# Altium schematic sheet Active_Electrode.SchDoc
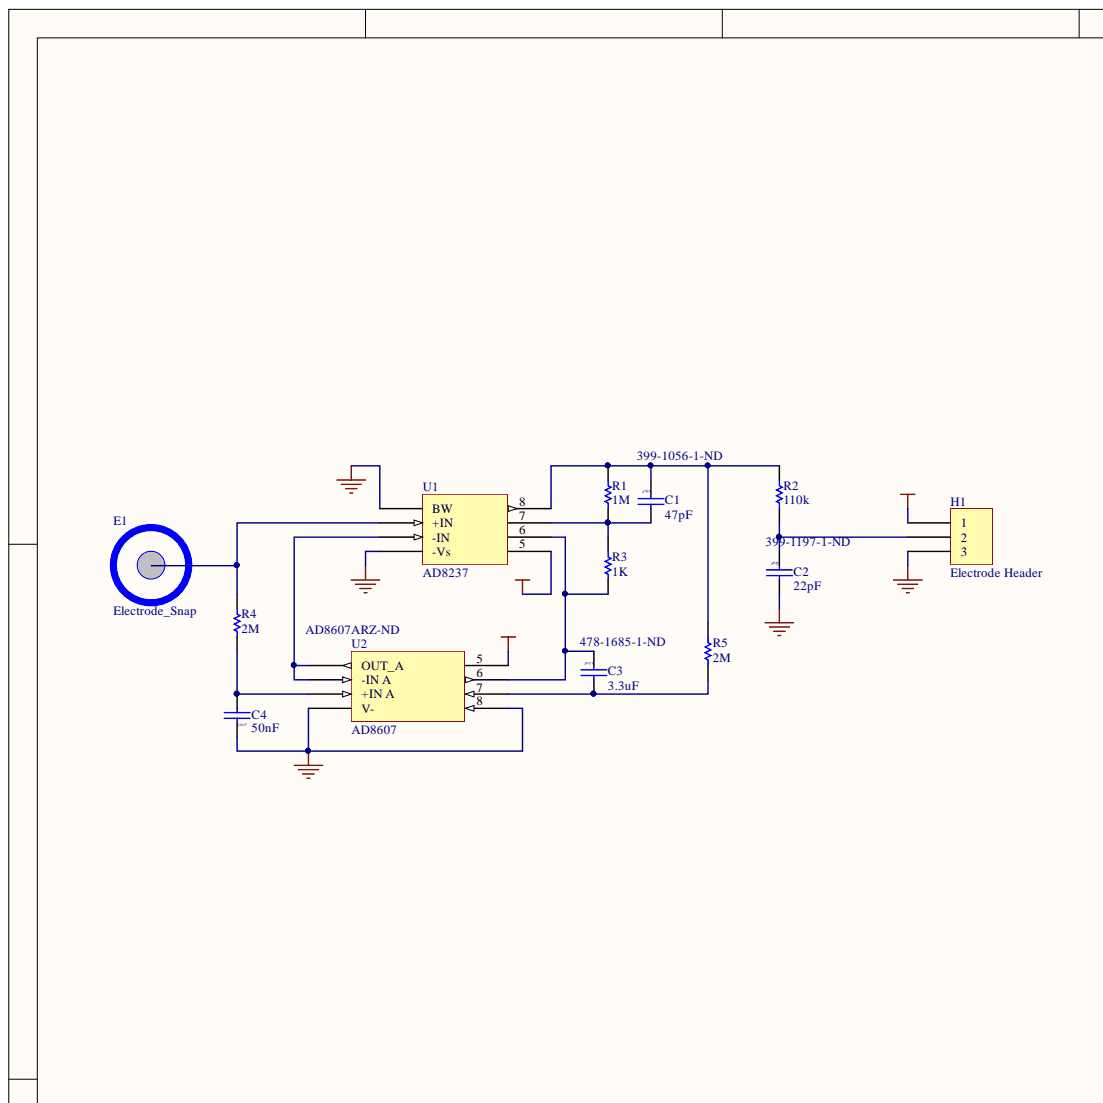
[diagram p0: Active_Electrode.SchDoc, top left]
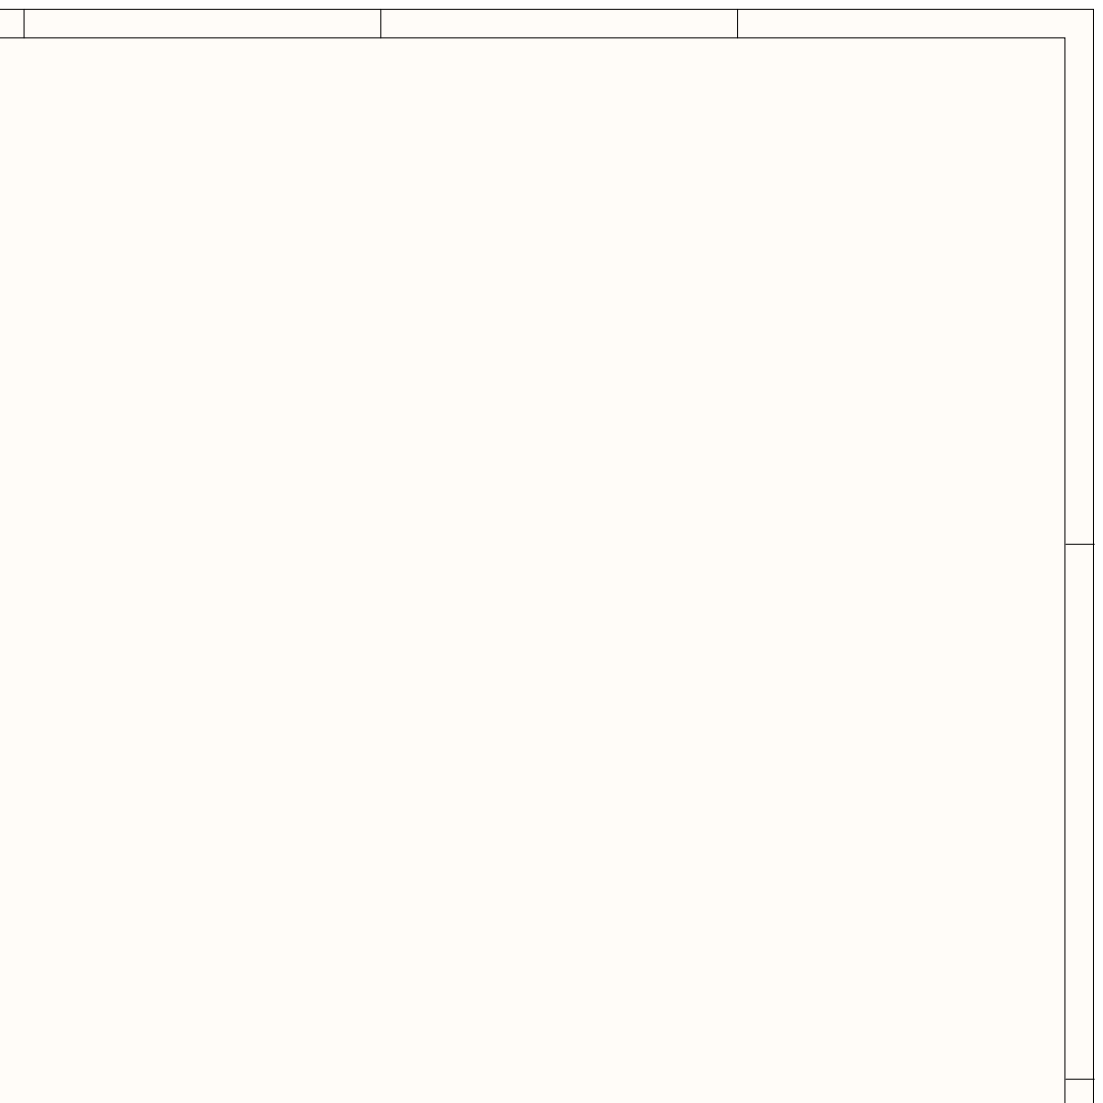
[diagram p1: Active_Electrode.SchDoc, top right]
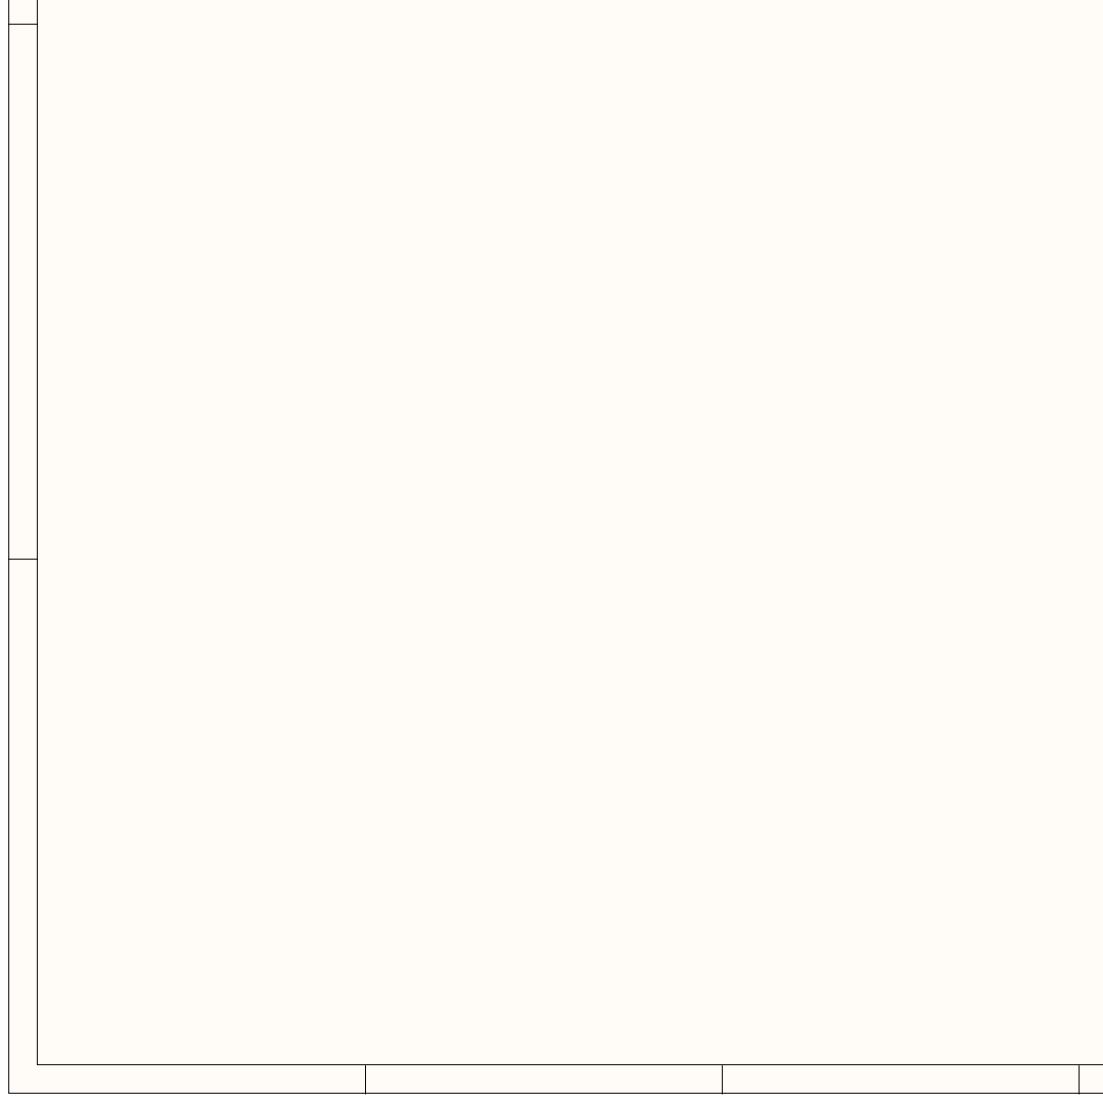
[diagram p2: Active_Electrode.SchDoc, bottom left]
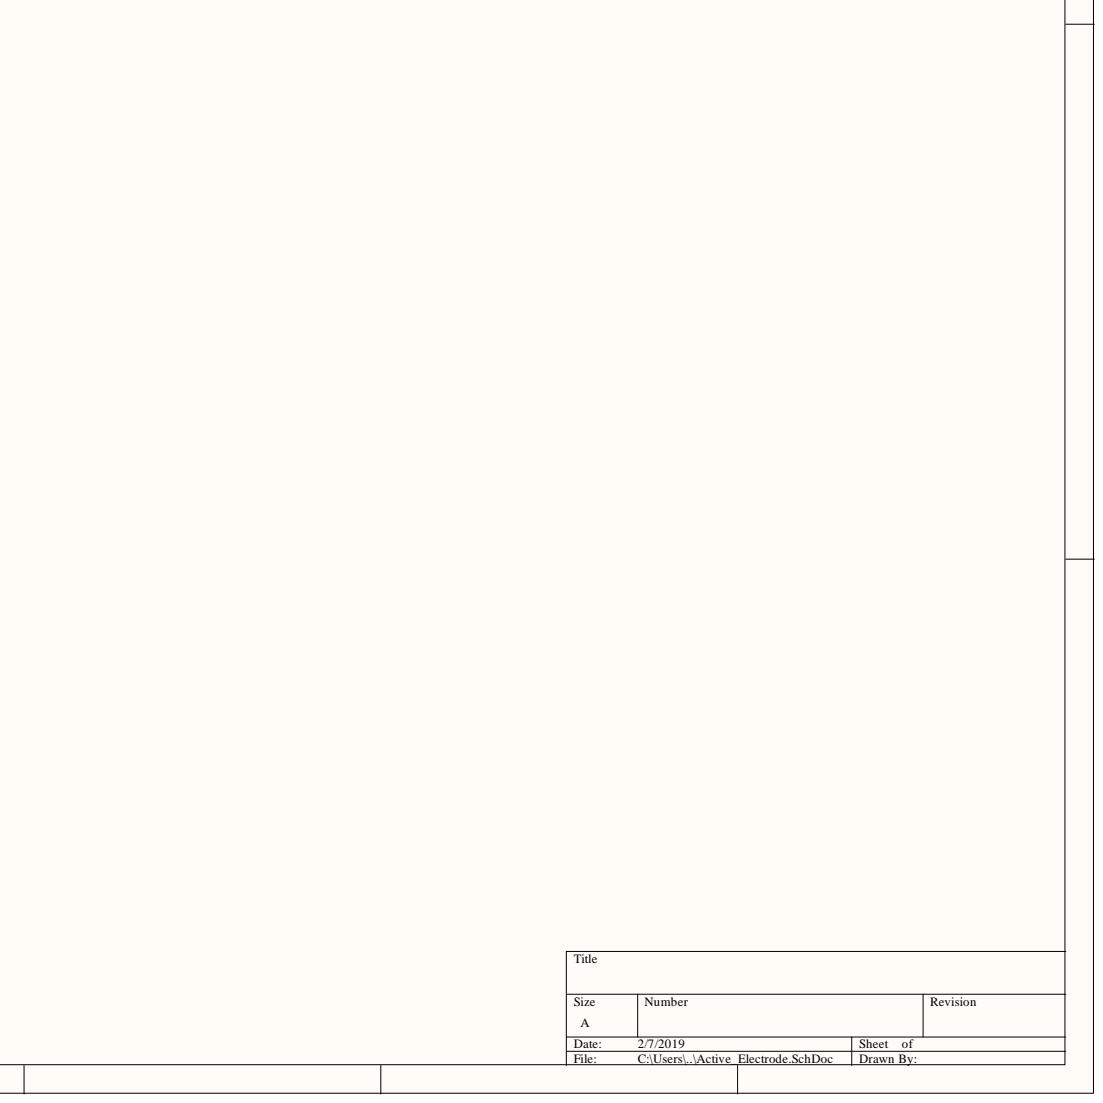
[diagram p3: Active_Electrode.SchDoc, bottom right]

## Parts
C1  47pF  Supplier Part Number 1: 399-1056-1-ND  [0402[IMP]]
C2  22pF  Supplier Part Number 2: 399-1197-1-ND  [0402[IMP]]
C3  3.3uF  Supplier Part Number 2: 478-1685-1-ND  [0402[IMP]]
C4  50nF  [0402[IMP]]
E1  Electrode_Snap  [Electrode_Socket]
H1  Electrode Header  [Electrode Header]
R1  1M  [0402[IMP]]
R2  110k  [0402[IMP]]
R3  1K  [0402[IMP]]
R4  2M  [0402[IMP]]
R5  2M  [0402[IMP]]
U1  AD8237  [MSOP-8]
U2  AD8607  Supplier Part Number 2: AD8607ARZ-ND  [MSOP-8]

## Nets
GND1: C2.1 C4.2 H1.3 U1.1(BW) U1.4(-Vs) U2.4(V-) U2.8
VCC1: H1.1 U1.5 U2.5
NetC1_1: C1.1 R1.1 R3.2 U1.7
NetC1_2: C1.2 R1.2 R2.2 R5.2 U1.8
NetC2_2: C2.2 H1.2 R2.1
NetC3_1: C3.1 R5.1 U2.7
NetC3_2: C3.2 R3.1 U1.6 U2.6
NetC4_1: C4.1 R4.1 U2.3(+IN_A)
NetE1_1: E1.1 R4.2 U1.2(+IN)
NetU1_3: U1.3(-IN) U2.1(OUT_A) U2.2(-IN_A)

## Library data of the parts
C1-C4 = CAPACITOR: CLASS: DISCRETE; CURRENT: CIMAX; DIST: FLAT; KNEE: CBMAX; MAX_TEMP: CTMAX; PSpiceTemplate: C^@REFDES %1 %2 ?TOLERANCE|C^@REFDES| @VALUE ?IC/IC=@IC/ TC=@TC1,@TC2 ?TOLERANCE|\n.model C^@REFDES CAP C=1 DEV=@TOLERANCE%|; SLOPE: CSMAX; TC1: 0; VOLTAGE: CMAX; Component Kind: Standard; Simulation: CAPACITOR; Supplier 1: Digi-Key; TC2: 0; Library Name: Biosense_Altium_Library.IntLib
  C1: Value: 47pF
  C2: Supplier 2: Digi-Key; Supplier Part Number 1: 311-1018-1-ND; Value: 22pF
  C3: Supplier 2: Digi-Key; Supplier Part Number 1: 311-1018-1-ND; Value: 3.3uF
  C4: Supplier Part Number 1: 478-1078-1-ND; Value: 50nF
R1-R5 = RESISTOR: Component Kind: Standard; Supplier 1: Digi-Key; Supplier Part Number 1: MCS0402-1.00K-CFCT-ND; Library Name: Biosense_Altium_Library.IntLib
  R1: Value: 1M
  R2: Value: 110k
  R3: Value: 1K
  R4 R5: Value: 2M
E1 = Electrode_Snap: Component Kind: Standard; Library Name: Biosense_Altium_Library.IntLib
H1 = Electrode Header: Component Kind: Standard; Library Name: Biosense_Altium_Library.IntLib
U1 = AD8237: Component Kind: Standard; Supplier 1: Digi-Key; Supplier Part Number 1: AD8237ARMZ-ND; Library Name: Biosense_Altium_Library.IntLib
U2 = AD8607: Component Kind: Standard; Supplier 1: Digi-Key; Supplier 2: Digi-Key; Supplier Part Number 1: AD8607ARMZ-R2CT-ND; Library Name: Biosense_Altium_Library.IntLib
